# Altium schematic sheet Sheet1.SchDoc(Sheet1)
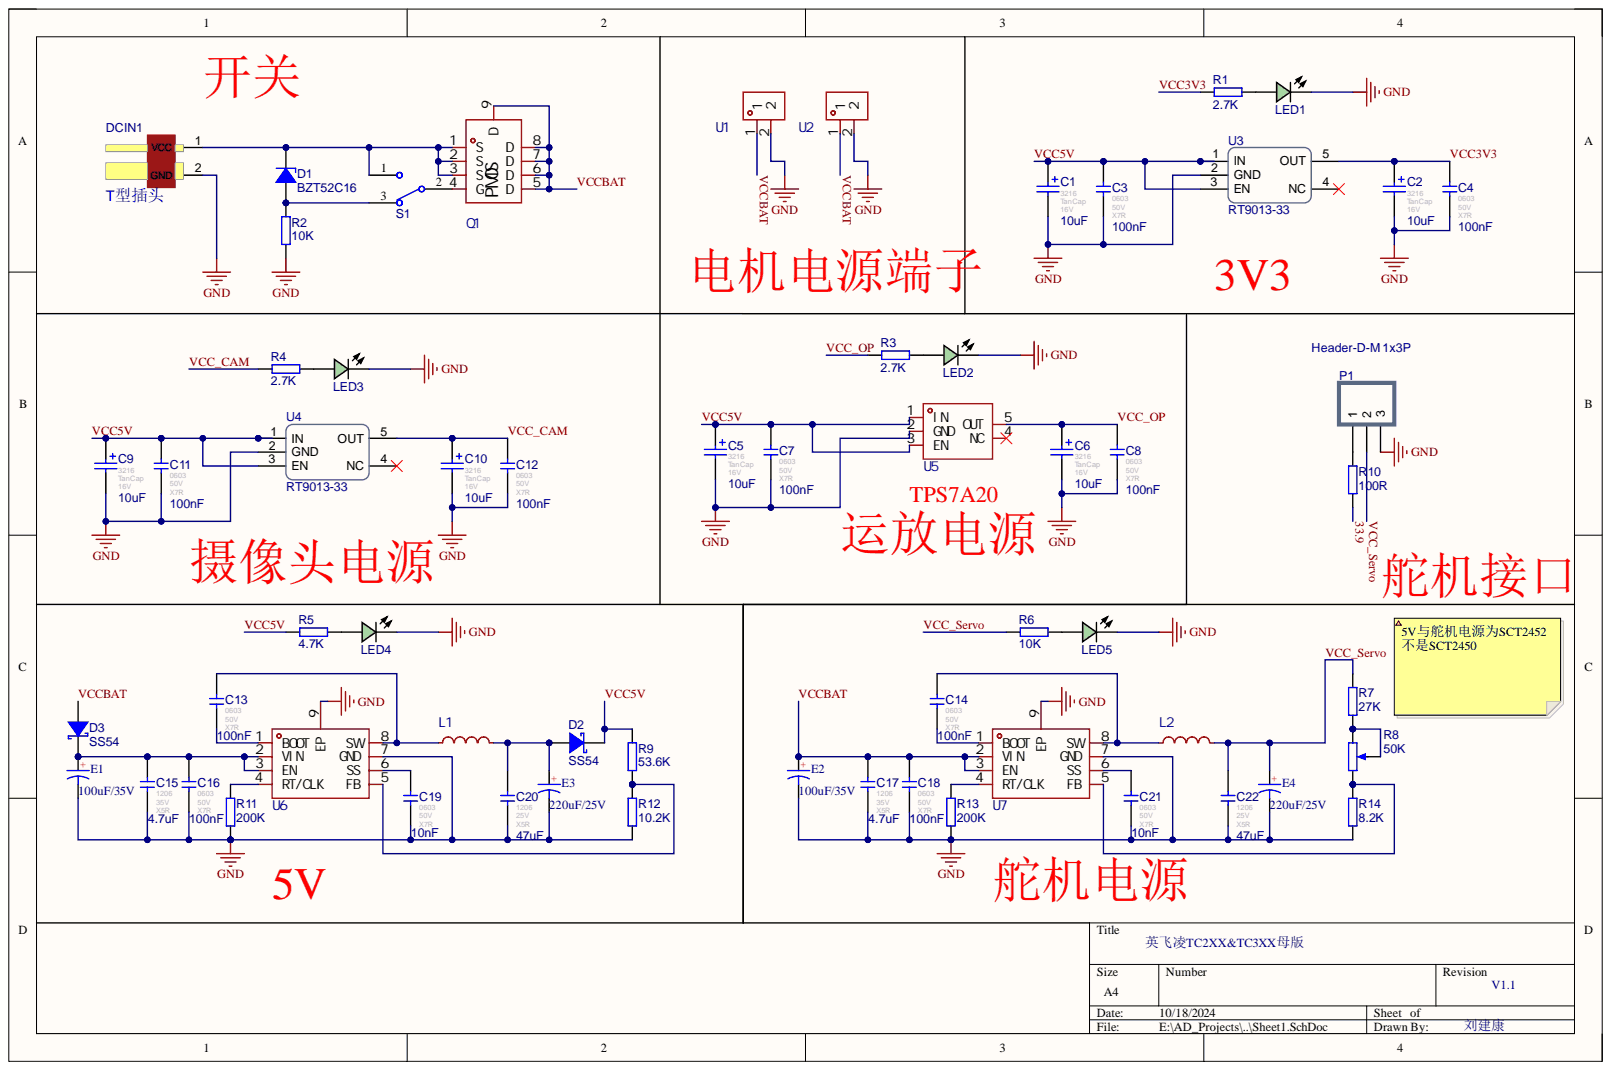
[diagram p0: Sheet1.SchDoc]

## Parts
C1  value 10uF  Type: TanCap  Voltage: 16V  [3216]  (hidden comment: 10uF 16V 钽电容)
C2  value 10uF  Type: TanCap  Voltage: 16V  [3216]  (hidden comment: 10uF 16V 钽电容)
C3  100nF 50V X7R  value 100nF  Type: X7R  Voltage: 50V  [0603]
C4  100nF 50V X7R  value 100nF  Type: X7R  Voltage: 50V  [0603]
C5  value 10uF  Type: TanCap  Voltage: 16V  [3216]  (hidden comment: 10uF 16V 钽电容)
C6  value 10uF  Type: TanCap  Voltage: 16V  [3216]  (hidden comment: 10uF 16V 钽电容)
C7  100nF 50V X7R  value 100nF  Type: X7R  Voltage: 50V  [0603]
C8  100nF 50V X7R  value 100nF  Type: X7R  Voltage: 50V  [0603]
C9  value 10uF  Type: TanCap  Voltage: 16V  [3216]  (hidden comment: 10uF 16V 钽电容)
C10  value 10uF  Type: TanCap  Voltage: 16V  [3216]  (hidden comment: 10uF 16V 钽电容)
C11  100nF 50V X7R  value 100nF  Type: X7R  Voltage: 50V  [0603]
C12  100nF 50V X7R  value 100nF  Type: X7R  Voltage: 50V  [0603]
C13  100nF 50V X7R  value 100nF  Type: X7R  Voltage: 50V  [0603]
C14  100nF 50V X7R  value 100nF  Type: X7R  Voltage: 50V  [0603]
C15  4.7uF 35V X5R  value 4.7uF  Type: X5R  Voltage: 35V  [1206]
C16  100nF 50V X7R  value 100nF  Type: X7R  Voltage: 50V  [0603]
C17  4.7uF 35V X5R  value 4.7uF  Type: X5R  Voltage: 35V  [1206]
C18  100nF 50V X7R  value 100nF  Type: X7R  Voltage: 50V  [0603]
C19  10nF 50V X7R  value 10nF  Type: X7R  Voltage: 50V  [0603]
C20  47uF 25V X5R  value 47uF  Type: X5R  Voltage: 25V  [1206]
C21  10nF 50V X7R  value 10nF  Type: X7R  Voltage: 50V  [0603]
C22  47uF 25V X5R  value 47uF  Type: X5R  Voltage: 25V  [1206]
D1  BZT52C16  [SOD-123-L]
D2  SS54  [SMA-L[DO-214AC]]
D3  SS54  [SMA-L[DO-214AC]]
DCIN1  T型插头  [Wired T-Header ThroughHole]
E1  100uF/35V  [CT 2.5*6.3*7 - C]
E2  100uF/35V  [CT 2.5*6.3*7 - C]
E3  220uF/25V  [CT 2.5*6.3*7 - C]
E4  220uF/25V  [CT 2.5*6.3*7 - C]
L1  5.6uH  [IND-SMD_L7.0-W6.6]  (value not drawn)
L2  5.6uH  [IND-SMD_L7.0-W6.6]  (value not drawn)
LED1  翠绿贴片LED  [LEDC1608(0603)-L]  (value not drawn)
LED2  翠绿贴片LED  [LEDC1608(0603)-L]  (value not drawn)
LED3  翠绿贴片LED  [LEDC1608(0603)-L]  (value not drawn)
LED4  翠绿贴片LED  [LEDC1608(0603)-L]  (value not drawn)
LED5  翠绿贴片LED  [LEDC1608(0603)-L]  (value not drawn)
P1  Header-D-M 1x3P  [HDR1X3-M]
Q1  WSD90P06DN56  [PDFN-8_L5.8-W4.9-P1.27-LS6.1-BL]  (value not drawn)
R1  value 2.7K  [RESC1608[0603]S-N]  (hidden comment: 2.7K ±1%)
R2  value 10K  [RESC1608[0603]S-N]  (hidden comment: 10K ±1%)
R3  value 2.7K  [RESC1608[0603]S-N]  (hidden comment: 2.7K ±1%)
R4  value 2.7K  [RESC1608[0603]S-N]  (hidden comment: 2.7K ±1%)
R5  value 4.7K  [RESC1608[0603]S-N]  (hidden comment: 4.7K ±1%)
R6  value 10K  [RESC1608[0603]S-N]  (hidden comment: 10K ±1%)
R7  value 27K  [RESC1608[0603]S-N]  (hidden comment: 27K ±1%)
R8  50K  [RESPOT3296W]
R9  value 53.6K  [RESC1608[0603]S-N]  (hidden comment: 53.6K ±1%)
R10  value 100R  [RESC1608[0603]S-N]  (hidden comment: 100R ±1%)
R11  value 200K  [RESC1608[0603]S-N]  (hidden comment: 200K ±1%)
R12  value 10.2K  [RESC1608[0603]S-N]  (hidden comment: 10.2K ±1%)
R13  value 200K  [RESC1608[0603]S-N]  (hidden comment: 200K ±1%)
R14  value 8.2K  [RESC1608[0603]S-N]  (hidden comment: 8.2K ±1%)
S1  SW-SPDT  [SS12D10]  (value not drawn)
U1  KF128-5.08-2P-AA  [CONN-TH_P5.08_KF128-5.08-2P]  (value not drawn)
U2  KF128-5.08-2P-AA  [CONN-TH_P5.08_KF128-5.08-2P]  (value not drawn)
U3  RT9013-33  [SOT-23-5]
U4  RT9013-33  [SOT-23-5]
U5  TPS7A2033PDBVR  [SOT-23-5_L2.9-W1.6-P0.95-LS2.8-BR]  (value not drawn)
U6  SCT2452STER  [ESOP-8_L4.9-W3.9-P1.27-LS6.0-BL-EP]  (value not drawn)
U7  SCT2452STER  [ESOP-8_L4.9-W3.9-P1.27-LS6.0-BL-EP]  (value not drawn)

## Nets
GND: C1.2 C2.2 C3.2 C4.2 C5.2 C6.2 C7.2 C8.2 C9.2 C10.2 C11.2 C12.2 C15.1 C16.1 C17.1 C18.1 C19.1 C20.1 C21.1 C22.1 DCIN1.2 E1.2 E2.2 E3.2 E4.2 LED1.C LED2.C LED3.C LED4.C LED5.C P1.3 R2.1 R11.1 R12.1 R13.1 R14.1 U1.2 U2.2 U3.2(GND) U4.2(GND) U5.2(GND) U6.7(GND) U6.9(EP) U7.7(GND) U7.9(EP)
33.9: R10.1
VCC3V3: C2.1 C4.1 R1.1 U3.5(OUT)
VCC5V: C1.1 C3.1 C5.1 C7.1 C9.1 C11.1 D2.K R5.1 R9.2 U3.1(IN) U3.3(EN) U4.1(IN) U4.3(EN) U5.1(IN) U5.3(EN)
VCC_CAM: C10.1 C12.1 R4.1 U4.5(OUT)
VCC_OP: C6.1 C8.1 R3.1 U5.5(OUT)
VCC_Servo: C22.2 E4.1 L2.2 P1.2 R6.1 R7.2
VCCBAT: C17.2 C18.2 D3.A E2.1 Q1.5(D) Q1.6(D) Q1.7(D) Q1.8(D) Q1.9(D) U1.1 U2.1 U7.2(VIN) U7.3(EN)
NetC13_1: C13.1 U6.1(BOOT)
NetC13_2: C13.2 L1.1 U6.8(SW)
NetC14_1: C14.1 U7.1(BOOT)
NetC14_2: C14.2 L2.1 U7.8(SW)
NetC15_2: C15.2 C16.2 D3.K E1.1 U6.2(VIN) U6.3(EN)
NetC19_2: C19.2 U6.6(SS)
NetC20_2: C20.2 D2.A E3.1 L1.2
NetC21_2: C21.2 U7.6(SS)
NetD1_A: D1.A R2.2 S1.3
NetD1_K: D1.K DCIN1.1 Q1.1(S) Q1.2(S) Q1.3(S) S1.1
NetLED1_A: LED1.A R1.2
NetLED2_A: LED2.A R3.2
NetLED3_A: LED3.A R4.2
NetLED4_A: LED4.A R5.2
NetLED5_A: LED5.A R6.2
NetP1_1: P1.1 R10.2
NetQ1_4: Q1.4(G) S1.2
NetR7_1: R7.1 R8.2 R8.ADJ
NetR8_1: R8.1 R14.2 U7.5(FB)
NetR9_1: R9.1 R12.2 U6.5(FB)
NetR11_2: R11.2 U6.4(RT/CLK)
NetR13_2: R13.2 U7.4(RT/CLK)

Not connected: U3 4(NC); U4 4(NC); U5 4(NC)

## Library data of the parts
C1 C2 C5 C6 C9 C10 = T-S 10uF 3216 16V: 贴片钽电容 10uF 3216 16V; Component Kind: Standard; Library Name: TC264_主板_V2.6.SCHLIB; Revision: July-2002: Re-released for DXP Platform.
C3 C4 C7 C8 C11-C14 C16 C18 C19 C21 = 100nF 0603 50V X7R: 贴片瓷片电容; MLCC; 0603(1608);; Component Kind: Standard; Library Name: TC264_主板_V2.6.SCHLIB; Revision: V1.0
C15 C17 = 4.7uF 1206 35V X5R: 贴片瓷片电容; MLCC; 1206(3216);; Component Kind: Standard; Library Name: TC264_主板_V2.6.SCHLIB; Revision: V1.0
C20 C22 = 47uF 1206 25V X5R: 贴片瓷片电容; MLCC; 1206(3216);; Component Kind: Standard; Library Name: TC264_主板_V2.6.SCHLIB; Revision: V1.0
D1 = Diode Zen: 稳压二极管 齐纳二极管  Zener Diode; Component Kind: Standard; Library Name: TC264_主板_V2.6.SCHLIB; Price(RMB): 0.000; Published: 8-Jun-2000; Revision: July-2002: Re-released for DXP Platform.; Publisher: Altium Limited
D2 D3 = SS54: SS54 肖特基二极管; Component Kind: Standard; Library Name: TC264_主板_V2.6.SCHLIB; Revision: V1.0; Value: SS54
DCIN1 = T_Header: Component Kind: Standard; Description: T型插头; Library Name: TC264_主板_V2.6.SCHLIB
E1-E4 = CS: 直插固态电容; 当前库版本V2022.12.25 @淘宝卖家: 最新版本发布https://shop463601444.taobao.com; BOM名称: 直插固态电容; Component Kind: Standard; Library Name: 0.1 - 电阻-电容-电感-保险丝-晶振-晶体-磁珠-电位器-法拉电容-馈通电容.SchLib
L1 L2 = MWSA0603S-5R6MT: 电感值:5.6uH;精度:±20%;额定电流:6A;饱和电流(Isat):6.5A;类型:-;; Manufacturer: Sunlord(顺络); Add into BOM: yes; DC Resistance (DCR): 42mΩ; Rated Current: 6A; Saturation Current (Isat): 6.5A; Tolerance: ±20%; Component Kind: Standard; Convert to PCB: yes; Datasheet: https://atta.szlcsc.com/upload/public/pdf/source/20231024/D9DB0CBBB5804BFBC9233D4A02F4216A.pdf; Device: MWSA0603S-5R6MT; LCSC Part Name: 5.6uH ±20% 6.5A; Library Name: Tc2XX_MotherBoard.SCHLIB; Manufacturer Part: MWSA0603S-5R6MT; PCB3D: IND-SMD_L7.0-W6.6-H3.0; Ratings: -; Supplier: LCSC; Supplier Footprint: SMD,7x6.6mm; Supplier Part: C408448; Symbol: MWSA0603S-5R6MT; Type: -; Value: 5.6uH
LED1-LED5 = LED Chip Green: Component Kind: Standard; Description: 翠绿贴片LED; Library Name: TC264_主板_V2.6.SCHLIB; Price(RMB): 0.00; Published: 8-Jun-2000; Revision: July-2002: Re-released for DXP Platform.; Publisher: Altium Limited
P1 = Header-D-M 1x3P: 1x3pin, 直插, 排针; Component Kind: Standard; Library Name: TC264_主板_V2.6.SCHLIB; Revision: V1.0; Value: Header-D-M 1x3P
Q1 = WSD90P06DN56: 类型:1个P沟道;漏源电压(Vdss):60V;连续漏极电流(Id):90A;功率(Pd):96W;导通电阻(RDS(on)@Vgs,Id):10.5mΩ@10V,30A;阈值电压(Vgs(th)@Id):1.85V@250uA;; Manufacturer: WINSOK(微硕); Add into BOM: yes; Continuous Drain Current (Id): 90A; Drain Source Voltage (Vdss): 60V; Input Capacitance (Ciss@Vds): 5.6nF@30V; Power Dissipation (Pd): 96W; Reverse Transfer Capacitance (Crss@Vds): 480pF@30V; Total Gate Charge (Qg@Vgs): 121nC@10V; Type: 1个P沟道; Component Kind: Standard; Convert to PCB: yes; Datasheet: https://atta.szlcsc.com/upload/public/pdf/source/20220729/279518A307255699200471987C1169DA.pdf; Device: WSD90P06DN56; Drain Source On Resistance (RDS(on)@Vgs,Id): 10.5mΩ@10V,30A; Gate Threshold Voltage (Vgs(th)@Id): 1.85V@250uA; JLCPCB Part Class: 扩展库; LCSC Part Name: 1个P沟道 耐压:60V 电流:90A; Library Name: Tc2XX_MotherBoard.SCHLIB; Manufacturer Part: WSD90P06DN56; Operating Temperature: -55℃~+150℃@(Tj); PCB3D: PDFN-8_L5.8-W4.9-P1.27-LS6.1-BL; Supplier: LCSC; Supplier Footprint: PDFN-8(4.9x5.8); Supplier Part: C719104; Symbol: WSD90P06DN56
R1 R3 R4 = Res-Chip_6: 贴片电阻; Power: 1/8W; Component Kind: Standard; Library Name: TC264_主板_V2.6.SCHLIB; Revision: V1.0; Tolerance: ±1%
R2 R6 = Res-Chip: 贴片电阻; Power: 1/8W; Component Kind: Standard; Library Name: TC264_主板_V2.6.SCHLIB; Revision: V1.0; Tolerance: ±1%
R5 R7 R9 R12 R14 = Res-Chip_3: 贴片电阻; Power: 1/8W; Component Kind: Standard; Library Name: TC264_主板_V2.6.SCHLIB; Revision: V1.0; Tolerance: ±1%
R8 = Res-Adj-3p: 可调电阻; Component Kind: Standard; Library Name: TC264_主板_V2.6.SCHLIB; Revision: V1.0; Value: 50K
R10 = Res-Chip_9: 贴片电阻; Power: 1/8W; Component Kind: Standard; Library Name: TC264_主板_V2.6.SCHLIB; Revision: V1.0; Tolerance: ±1%
R11 R13 = Res-Chip_1: 贴片电阻; Power: 1/8W; Component Kind: Standard; Library Name: TC264_主板_V2.6.SCHLIB; Revision: V1.0; Tolerance: ±1%
S1 = SW-SPDT: 单刀双掷开关 3脚船型、钮子、拨动开关 SPDT Subminiature Toggle Switch; Component Kind: Standard; Library Name: TC264_主板_V2.6.SCHLIB; Price(RMB): 0.000; Published: 24-Mar-1999; Publisher: Altium Limited
U1 U2 = KF128-5.08-2P-AA: 结构:1x2P;间距:5.08mm;颜色:绿色;安装方式:直插;额定电流:24A;额定电压:250V;排数:1;线规 - AWG:12~22;线规 - 平方毫米:2.5;每排PIN数:2;工作温度范围:-40℃~+105℃;; Manufacturer: KEFA(科发); Add into BOM: yes; Current Rating (Max): 24A; Number of PINs Per Row: 2; Pitch: 5.08mm; Structure: 1x2P; Voltage Rating (Max): 250V; Wire Gauge - AWG: 12~22; Wire Gauge - mm2: 2.5; Color: 绿色; Component Kind: Standard; Convert to PCB: yes; Datasheet: https://atta.szlcsc.com/upload/public/pdf/source/20230317/DADFED05827EAD48C1D5B8C3D4CF88F4.pdf; Device: KF128-5.08-2P-AA; LCSC Part Name: 5.08mm 1x2P 排数:1 每排P数:2 直插; Library Name: Tc2XX_MotherBoard.SCHLIB; Manufacturer Part: KF128-5.08-2P-AA; Mounting Style: 直插; Number of Pins: 2P; Number of Rows: 1; Operating Temperature Range: -40℃~+105℃; Supplier: LCSC; Supplier Footprint: 插件,P=5.08mm; Supplier Part: C474952; Symbol: KF128-5.08-2P
U3 U4 = RT9013-33: 3.3V 500mA SOT-23-5 LDO; Component Kind: Standard; Library Name: TC264_主板_V2.6.SCHLIB
U5 = TPS7A2033PDBVR: 输出类型:固定;最大输入电压:6V;输出电压:3.3V;输出电流:300mA;输出极性:正极;; Manufacturer: TI(德州仪器); Add into BOM: yes; Dropout Voltage: 140mV@(300mA); Maximum Input Voltage: 6V; Number of Outputs: 1; Output Current: 300mA; Output Polarity: 正极; Output Type: 固定; Output Voltage: 3.3V; standby current: 15uA; Component Kind: Standard; Convert to PCB: yes; Datasheet: https://www.ti.com/cn/lit/gpn/tps7a20; Device: TPS7A2033PDBVR; Feature: 防静电保护；软启动；浪涌保护；低ESR；热保护(TSD)；欠压闭锁；过流保护(OCP)；带使能；防倒灌; LCSC Part Name: 具有高 PSRR 的 300mA、超低噪声、低 IQ、低压降 (LDO) 线性稳压器; Library Name: Tc2XX_MotherBoard.SCHLIB; Manufacturer Part: TPS7A2033PDBVR; Operating Temperature: -40℃~+125℃@(Tj); PCB3D: SOT-23-5_L2.9-W1.6-P0.95-LS2.8-BR; Supplier: LCSC; Supplier Footprint: SOT-23-5; Supplier Part: C2862740; Symbol: TPS7A2033PDBVR
U6 U7 = SCT2452STER: Manufacturer: SCT(芯洲科技); Add into BOM: yes; Component Kind: Standard; Convert to PCB: yes; Datasheet: https://atta.szlcsc.com/upload/public/pdf/source/20200810/C719314_51D59A304A4FCEEE53813D61EC9CAF9E.pdf; Device: SCT2452STER; LCSC Part Name: SCT2452STER; Library Name: Tc2XX_MotherBoard.SCHLIB; Manufacturer Part: SCT2452STER; PCB3D: ESOP-8_L4.9-W3.9-H1.6-LS6.0-P1.27; Supplier: LCSC; Supplier Footprint: ESOP-8; Supplier Part: C719314; Symbol: SCT2452STER
